# Altium schematic sheet SW6208+A+A+B+C+L+快充188数码管.SchDoc
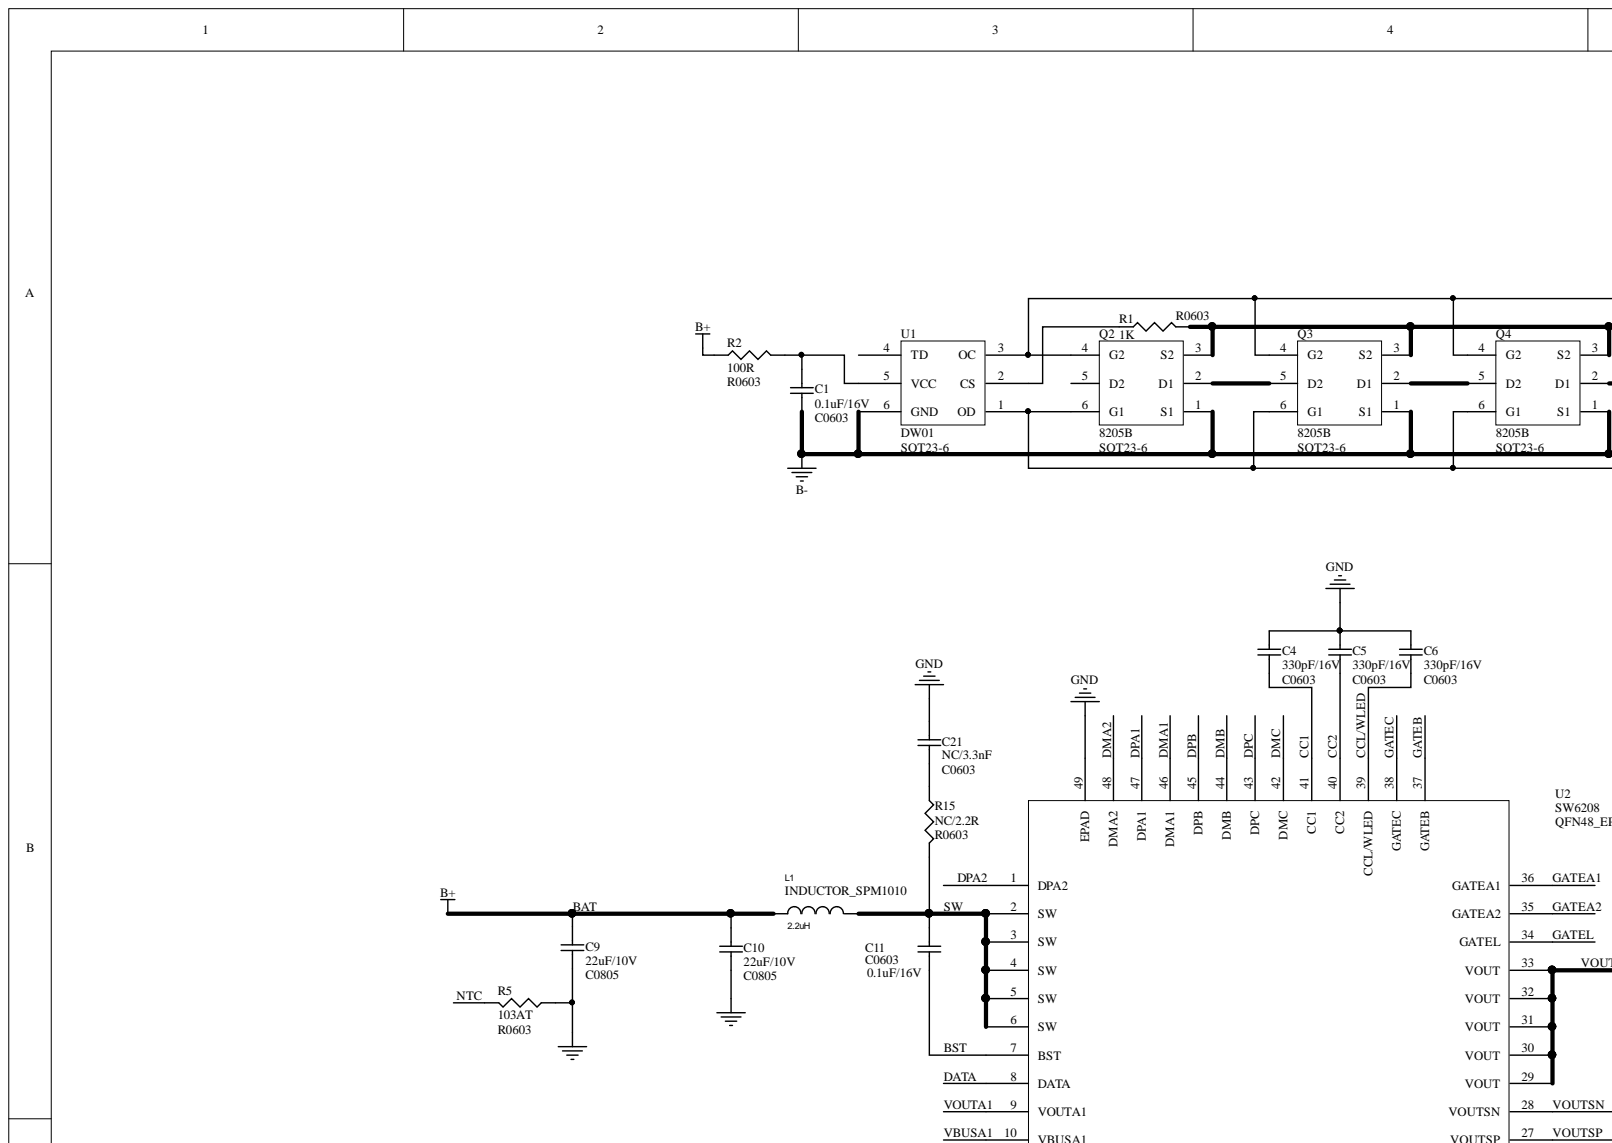
[diagram p0: SW6208+A+A+B+C+L+快充188数码管.SchDoc, top left]
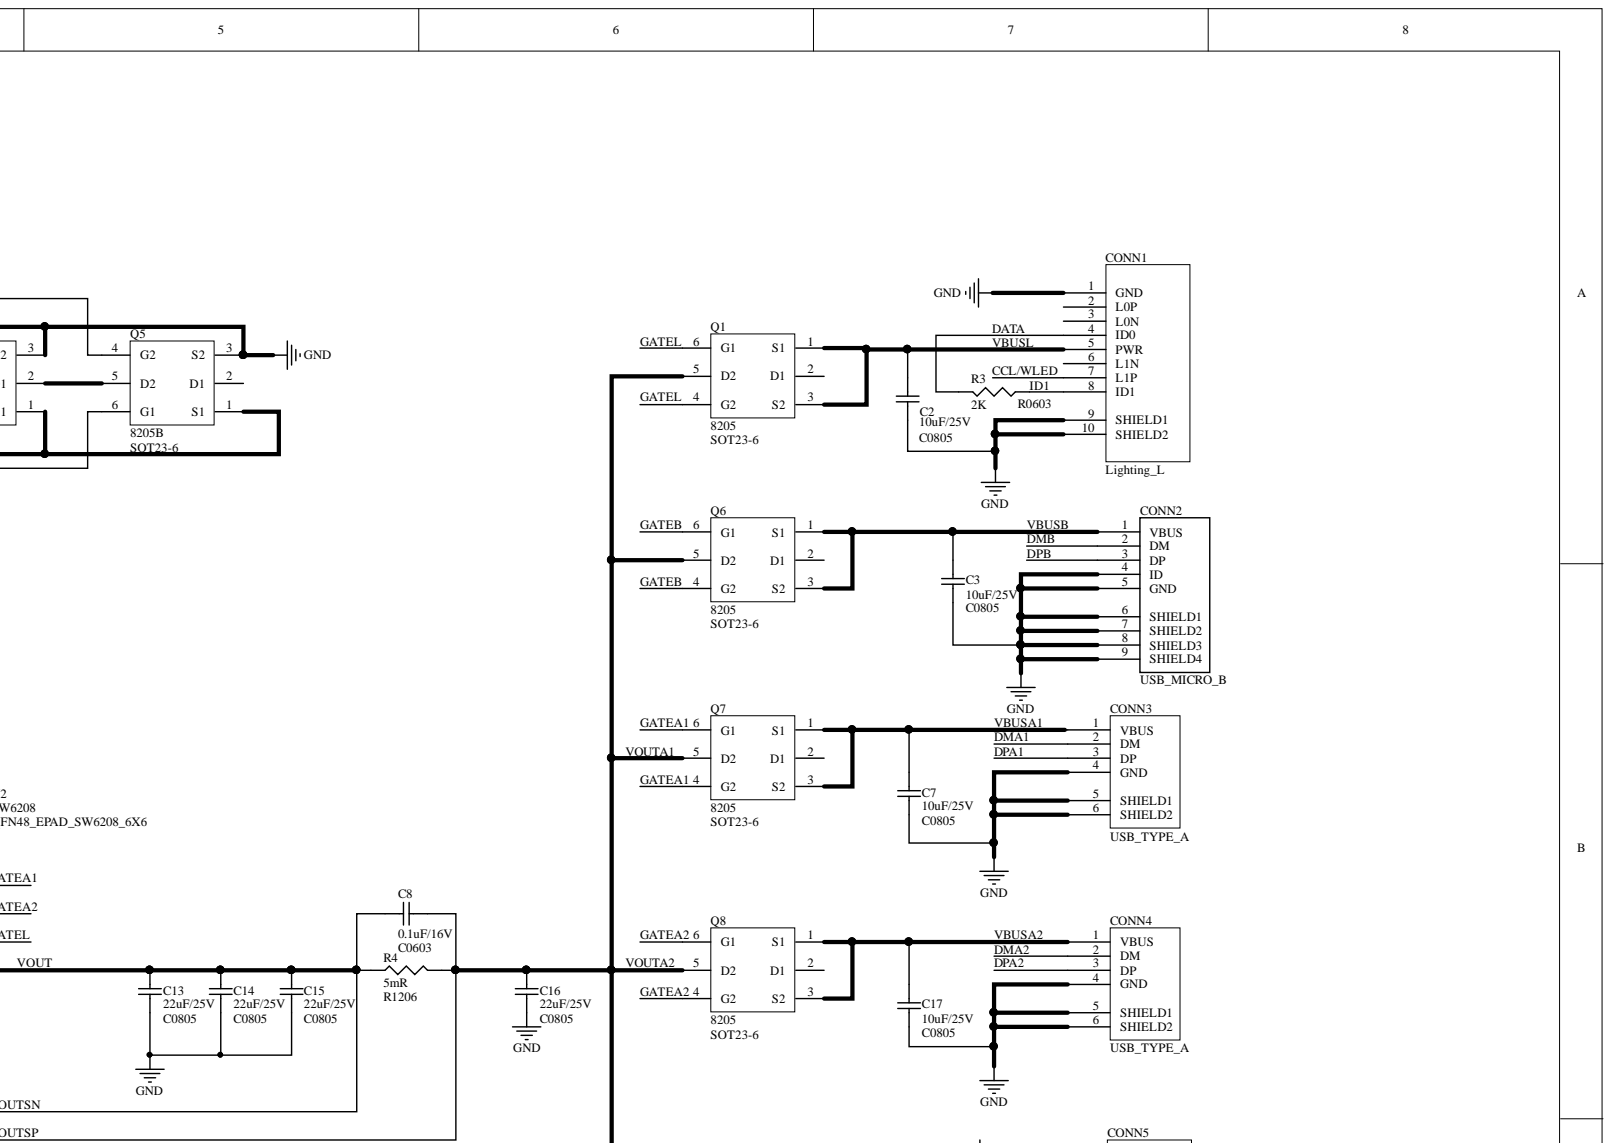
[diagram p1: SW6208+A+A+B+C+L+快充188数码管.SchDoc, top right]
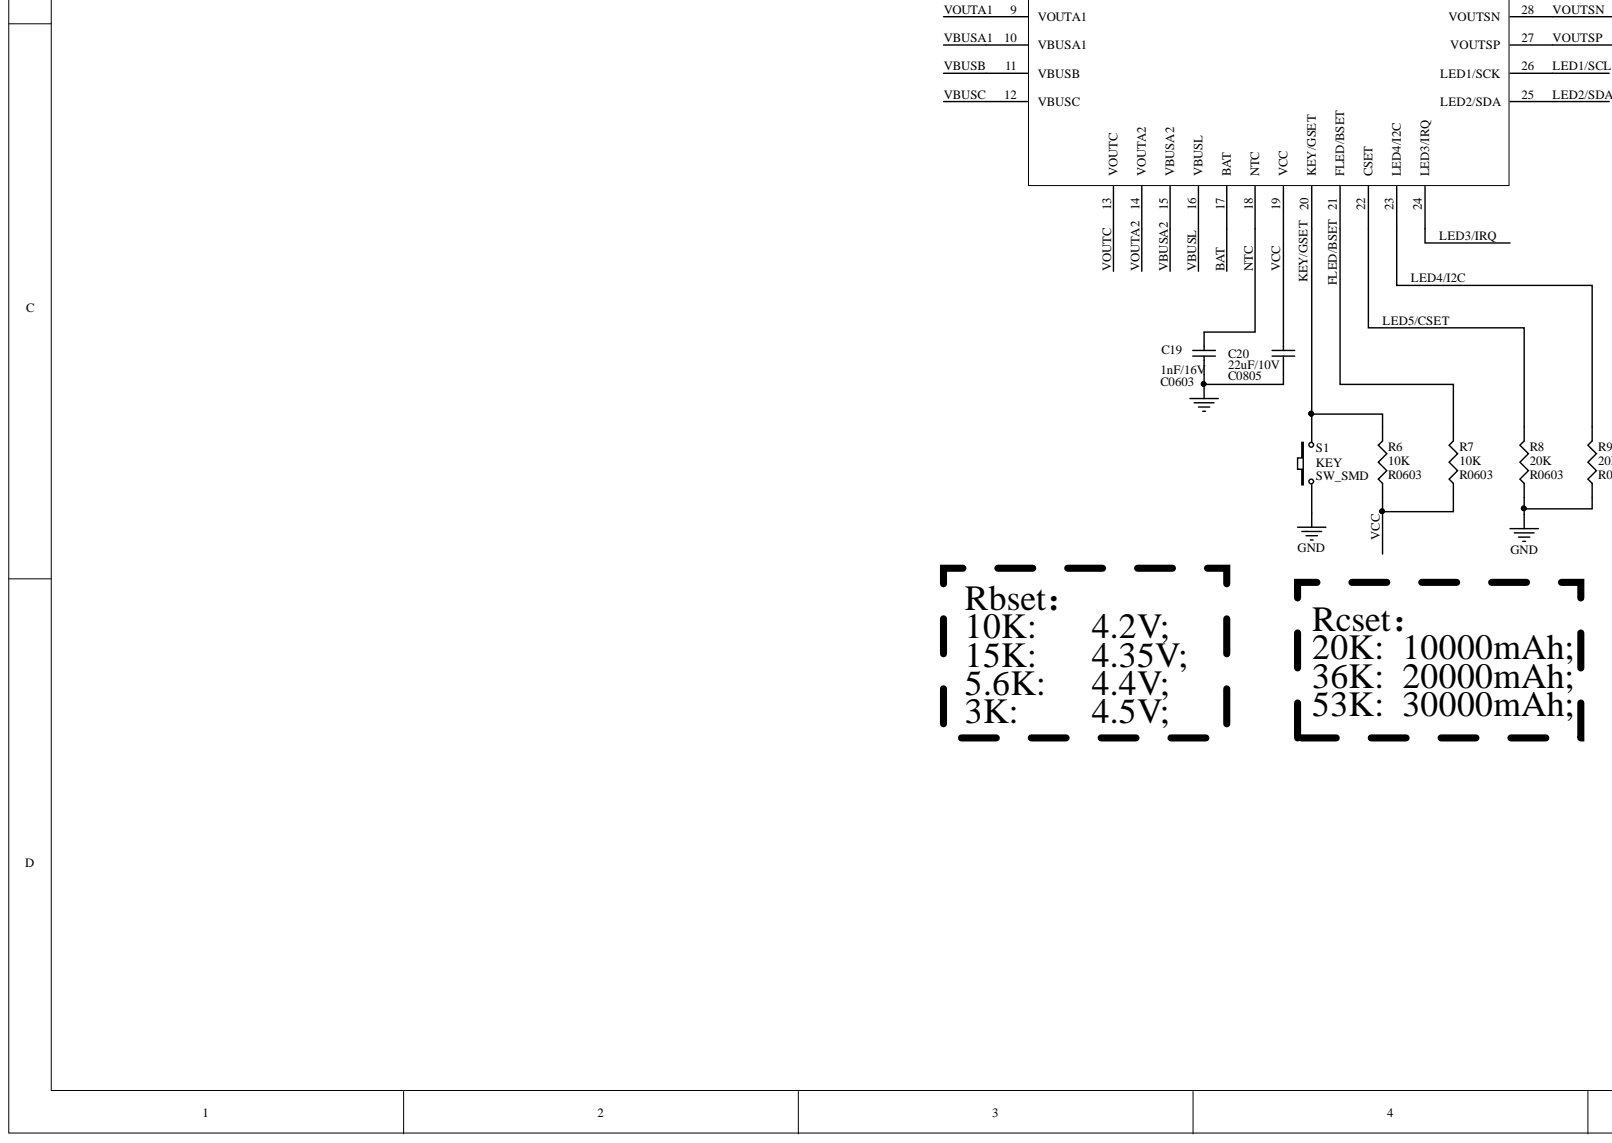
[diagram p2: SW6208+A+A+B+C+L+快充188数码管.SchDoc, bottom left]
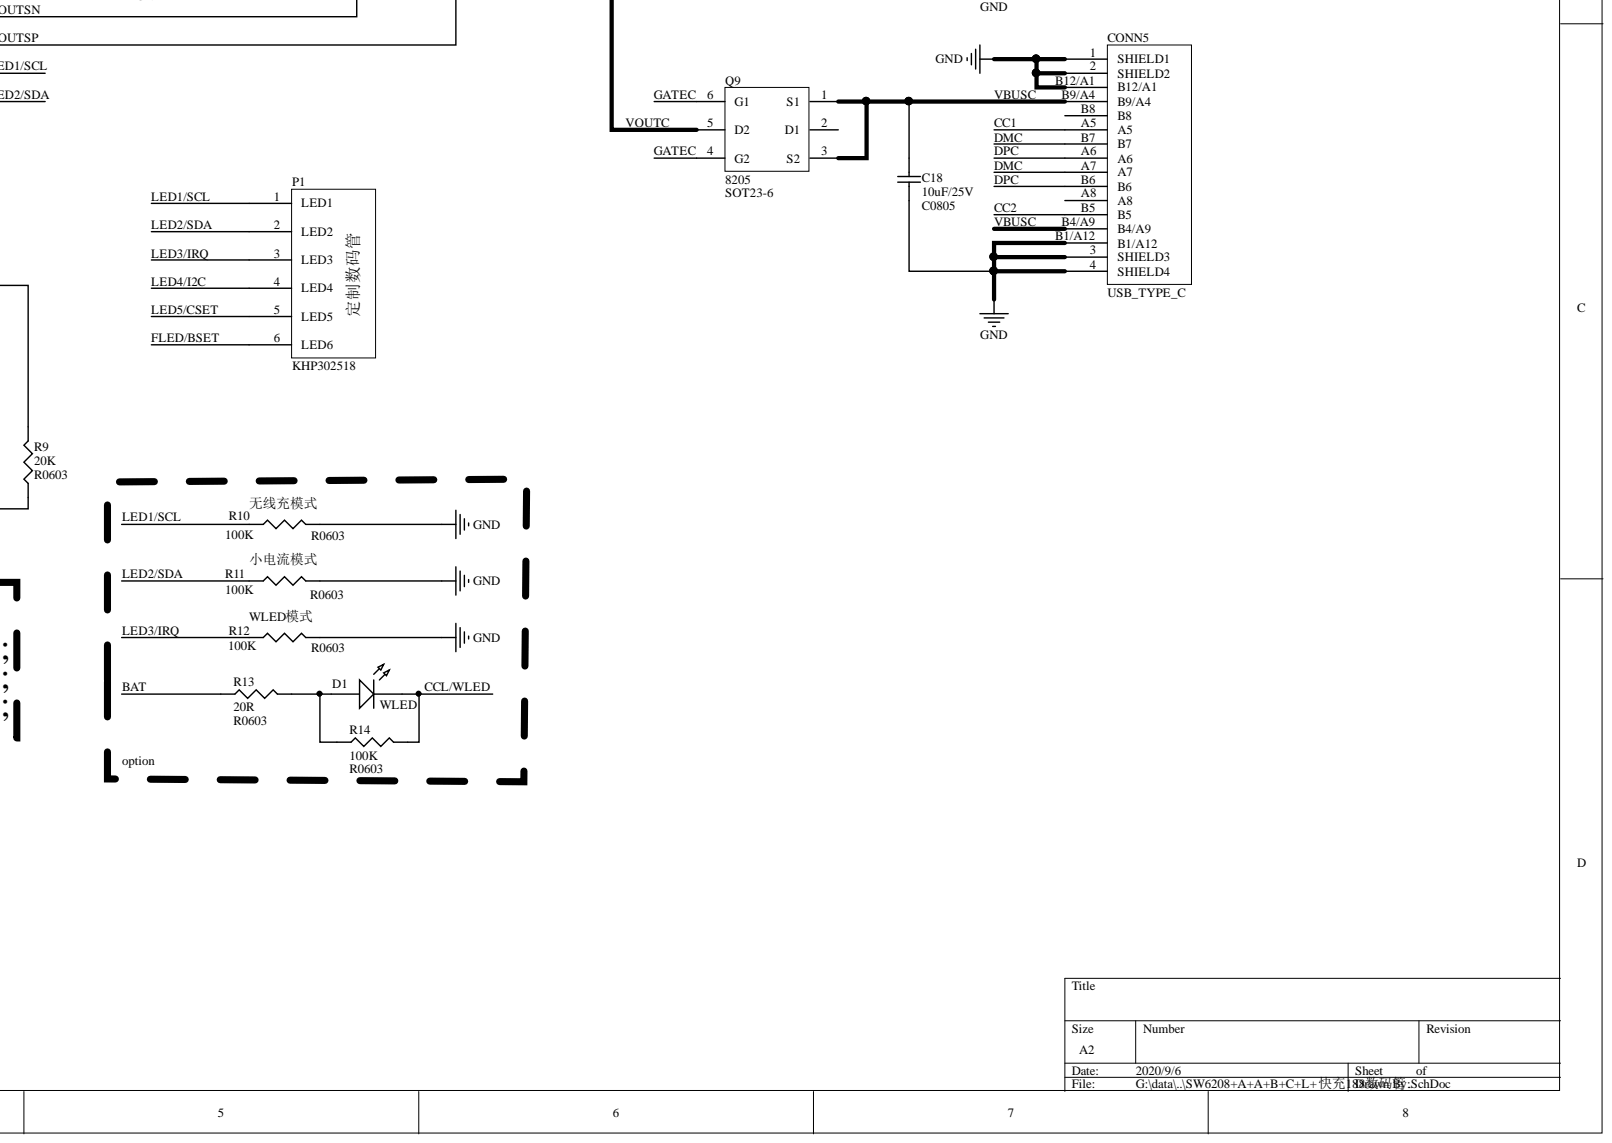
[diagram p3: SW6208+A+A+B+C+L+快充188数码管.SchDoc, bottom right]

## Parts
C1  0.1uF/16V  [C0603]
C2  10uF/25V  [C0805]
C3  10uF/25V  [C0805]
C4  330pF/16V  [C0603]
C5  330pF/16V  [C0603]
C6  330pF/16V  [C0603]
C7  10uF/25V  [C0805]
C8  0.1uF/16V  [C0603]
C9  22uF/10V  [C0805]
C10  22uF/10V  [C0805]
C11  0.1uF/16V  [C0603]
C13  22uF/25V  [C0805]
C14  22uF/25V  [C0805]
C15  22uF/25V  [C0805]
C16  22uF/25V  [C0805]
C17  10uF/25V  [C0805]
C18  10uF/25V  [C0805]
C19  1nF/16V  [C0603]
C20  22uF/10V  [C0805]
C21  NC/3.3nF  [C0603]
CONN1  Lighting_L  [Lighting_L]
CONN2  USB_MICRO_B  [USB_MICRO_B]
CONN3  USB_TYPE_A  [USB_TYPE_A]
CONN4  USB_TYPE_A  [USB_TYPE_A]
CONN5  USB_TYPE_C  [USB_TYPE_C]
D1  WLED  [LED_5MM]
L1  2.2uH  [INDUCTOR_SPM1010]
P1  KHP302518  [KHP-SEG-6]
Q1  8205  [SOT23-6]
Q2  8205B  [SOT23-6]
Q3  8205B  [SOT23-6]
Q4  8205B  [SOT23-6]
Q5  8205B  [SOT23-6]
Q6  8205  [SOT23-6]
Q7  8205  [SOT23-6]
Q8  8205  [SOT23-6]
Q9  8205  [SOT23-6]
R1  1K  [R0603]
R2  100R  [R0603]
R3  2K  [R0603]
R4  5mR  [R1206]
R5  103AT  [R0603]
R6  10K  [R0603]
R7  10K  [R0603]
R8  20K  [R0603]
R9  20K  [R0603]
R10  100K  [R0603]
R11  100K  [R0603]
R12  100K  [R0603]
R13  20R  [R0603]
R14  100K  [R0603]
R15  NC/2.2R  [R0603]
S1  KEY  [SW_SMD]
U1  DW01  [SOT23-6]
U2  SW6208  [QFN48_EPAD_SW6208_6X6]

## Nets
B-: C1.1 Q2.1(S1) Q3.1(S1) Q4.1(S1) Q5.1(S1) U1.6(GND)
GND: C2.1 C3.1 C4.2 C5.2 C6.2 C7.1 C9.1 C10.1 C13.1 C14.1 C15.1 C16.1 C17.1 C18.1 C19.2 C20.2 C21.2 CONN1.1(GND) CONN1.9(SHIELD1) CONN1.10(SHIELD2) CONN2.4(ID) CONN2.5(GND) CONN2.6(SHIELD1) CONN2.7(SHIELD2) CONN2.8(SHIELD3) CONN2.9(SHIELD4) CONN3.4(GND) CONN3.5(SHIELD1) CONN3.6(SHIELD2) CONN4.4(GND) CONN4.5(SHIELD1) CONN4.6(SHIELD2) CONN5.1(SHIELD1) CONN5.2(SHIELD2) CONN5.3(SHIELD3) CONN5.4(SHIELD4) CONN5.B1/A12 CONN5.B12/A1 Q2.3(S2) Q3.3(S2) Q4.3(S2) Q5.3(S2) R1.2 R5.1 R8.1 R9.1 R10.2 R11.2 R12.2 S1.1 U2.49(EPAD)
BAT: C9.2 C10.2 L1.1 R2.1 R13.1 U2.17(BAT)
BST: C11.1 U2.7(BST)
CC1: C4.1 CONN5.A5 U2.41(CC1)
CC2: C5.1 CONN5.B5 U2.40(CC2)
CCL/WLED: C6.1 CONN1.7(L1P) D1.2 R14.2 U2.39(CCL/WLED)
DATA: CONN1.4(ID0) R3.2 U2.8(DATA)
DMA1: CONN3.2(DM) U2.46(DMA1)
DMA2: CONN4.2(DM) U2.48(DMA2)
DMB: CONN2.2(DM) U2.44(DMB)
DMC: CONN5.A7 CONN5.B7 U2.42(DMC)
DPA1: CONN3.3(DP) U2.47(DPA1)
DPA2: CONN4.3(DP) U2.1(DPA2)
DPB: CONN2.3(DP) U2.45(DPB)
DPC: CONN5.A6 CONN5.B6 U2.43(DPC)
FLED/BSET: P1.6(LED6) R7.2 U2.21(FLED/BSET)
GATEA1: Q7.4(G2) Q7.6(G1) U2.36(GATEA1)
GATEA2: Q8.4(G2) Q8.6(G1) U2.35(GATEA2)
GATEB: Q6.4(G2) Q6.6(G1) U2.37(GATEB)
GATEC: Q9.4(G2) Q9.6(G1) U2.38(GATEC)
GATEL: Q1.4(G2) Q1.6(G1) U2.34(GATEL)
ID1: CONN1.8(ID1) R3.1
LED1/SCL: P1.1(LED1) R10.1 U2.26(LED1/SCK)
LED2/SDA: P1.2(LED2) R11.1 U2.25(LED2/SDA)
LED3/IRQ: P1.3(LED3) R12.1 U2.24(LED3/IRQ)
LED4/I2C: P1.4(LED4) R9.2 U2.23(LED4/I2C)
LED5/CSET: P1.5(LED5) R8.2 U2.22(CSET)
NTC: C19.1 R5.2 U2.18(NTC)
SW: C11.2 L1.2 R15.2 U2.2(SW) U2.3(SW) U2.4(SW) U2.5(SW) U2.6(SW)
VBUSA1: C7.2 CONN3.1(VBUS) Q7.1(S1) Q7.3(S2) U2.10(VBUSA1)
VBUSA2: C17.2 CONN4.1(VBUS) Q8.1(S1) Q8.3(S2) U2.15(VBUSA2)
VBUSB: C3.2 CONN2.1(VBUS) Q6.1(S1) Q6.3(S2) U2.11(VBUSB)
VBUSC: C18.2 CONN5.B4/A9 CONN5.B9/A4 Q9.1(S1) Q9.3(S2) U2.12(VBUSC)
VBUSL: C2.2 CONN1.5(PWR) Q1.1(S1) Q1.3(S2) U2.16(VBUSL)
VCC: C20.1 R6.1 R7.1 U2.19(VCC)
VOUT: C8.1 C13.2 C14.2 C15.2 R4.1 U2.28(VOUTSN) U2.29(VOUT) U2.30(VOUT) U2.31(VOUT) U2.32(VOUT) U2.33(VOUT)
VOUTA1: C8.2 C16.2 Q1.5(D2) Q6.5(D2) Q7.5(D2) Q8.5(D2) Q9.5(D2) R4.2 U2.9(VOUTA1) U2.13(VOUTC) U2.14(VOUTA2) U2.27(VOUTSP)
NetC1_2: C1.2 R2.2 U1.5(VCC)
NetC21_1: C21.1 R15.1
NetD1_1: D1.1 R13.2 R14.1
NetQ2_2: Q2.2(D1) Q3.5(D2)
NetQ2_4: Q2.4(G2) Q3.4(G2) Q4.4(G2) Q5.4(G2) U1.3(OC)
NetQ2_6: Q2.6(G1) Q3.6(G1) Q4.6(G1) Q5.6(G1) U1.1(OD)
NetQ3_2: Q3.2(D1) Q4.5(D2)
NetQ4_2: Q4.2(D1) Q5.5(D2)
NetR1_1: R1.1 U1.2(CS)
NetR6_2: R6.2 S1.2 U2.20(KEY/GSET)
KEY/GSET:

Not connected: CONN1 2(L0P) 3(L0N) 6(L1N); CONN5 A8 B8; Q1 2(D1); Q2 5(D2); Q5 2(D1); Q6 2(D1); Q7 2(D1); Q8 2(D1); Q9 2(D1); U1 4(TD)

## Library data of the parts
C1 C4-C6 C8 C11 C19 C21 = CAP0603: Capacitor; Value: 22uF/16V; Component Kind: Standard; LatestRevisionDate: 17-Jul-2002; LatestRevisionNote: Re-released for DXP Platform.; PackageDescription: Radial Cap, Thru-Hole; 2 Leads; 0.3 in Pin Spacing; PackageReference: RAD-0.3; Published: 8-Jun-2000; Library Name: 参考设计器件原理图更新.SchLib; Publisher: Altium Limited
C2 C3 C7 C9 C10 C17 C18 C20 = CAP0805: Capacitor; Value: 22uF/25V; Component Kind: Standard; LatestRevisionDate: 17-Jul-2002; LatestRevisionNote: Re-released for DXP Platform.; PackageDescription: Radial Cap, Thru-Hole; 2 Leads; 0.3 in Pin Spacing; PackageReference: RAD-0.3; Published: 8-Jun-2000; Library Name: 参考设计器件原理图更新.SchLib; Publisher: Altium Limited
C13-C16 = CAP0805: Capacitor; Component Kind: Standard; LatestRevisionDate: 17-Jul-2002; LatestRevisionNote: Re-released for DXP Platform.; PackageDescription: Radial Cap, Thru-Hole; 2 Leads; 0.3 in Pin Spacing; PackageReference: RAD-0.3; Published: 8-Jun-2000; Value: 22uF/25V; Library Name: 参考设计器件原理图更新.SchLib; Publisher: Altium Limited
CONN1 = LIGHTING_PORT: Component Kind: Standard; Description: Lighting_L; Value: Lighting_L; Library Name: 参考设计器件原理图更新.SchLib
D1 = WLED: Value: FLED; Component Kind: Standard; Description: WLED; LatestRevisionDate: 08-Jul-2005; LatestRevisionNote: Mech Layer 1 removed.; PackageDescription: LED; 2 Leads; PackageReference: LED-0; Published: 8-Jun-2000; Library Name: 参考设计器件原理图更新.SchLib; Publisher: Altium Limited
L1 = INDUCTOR_SPM1010: INDUCTOR; Component Kind: Standard; Value: 2.2uH; Library Name: 参考设计器件原理图更新.SchLib
Q1 Q6-Q9 = 8205: NMOS; Component Kind: Standard; Footprinf: SOT23-6; Value: 8205; Library Name: 参考设计器件原理图更新.SchLib
Q2-Q5 = 8205: NMOS; Value: 8205; Component Kind: Standard; Footprinf: SOT23-6; Library Name: 参考设计器件原理图更新.SchLib
R1-R3 R5 R8-R15 = RES_0603: Resistor; Value: 10K; Component Kind: Standard; LatestRevisionDate: 29-May-2009; LatestRevisionNote: IPC-7351 Footprint Added.; PackageDescription: Chip Resistor; PackageReference: J1-0603; Published: 8-Jun-2000; Library Name: 参考设计器件原理图更新.SchLib; Publisher: Altium Limited
R4 = RES_1206: Resistor; Value: 10K; Component Kind: Standard; LatestRevisionDate: 29-May-2009; LatestRevisionNote: IPC-7351 Footprint Added.; PackageDescription: Chip Resistor; PackageReference: J1-0603; Published: 8-Jun-2000; Library Name: 参考设计器件原理图更新.SchLib; Publisher: Altium Limited
R6 R7 = RES_0603: Resistor; Component Kind: Standard; LatestRevisionDate: 29-May-2009; LatestRevisionNote: IPC-7351 Footprint Added.; PackageDescription: Chip Resistor; PackageReference: J1-0603; Published: 8-Jun-2000; Value: 10K; Library Name: 参考设计器件原理图更新.SchLib; Publisher: Altium Limited
S1 = SW K: Component Kind: Standard; Description: KEY; Footprinf: SW_SMD; Value: KEY; Library Name: 参考设计器件原理图更新.SchLib
U1 = DW01: BAT_PROTECT_IC; Component Kind: Standard; Value: DW01; Library Name: 参考设计器件原理图更新.SchLib
U2 = SW6208: IC; Component Kind: Standard; Library Name: 芯片型号原理图.SchLib
CONN2 = USB_MICRO_B: Component Kind: Standard; Description: USB_MICRO_B; Footprintf: MICRO_B; Value: USB_MICRO_B; Library Name: 参考设计器件原理图更新.SchLib
CONN3 CONN4 = USB_TYPE_A: Component Kind: Standard; Description: USB_TYPE_A; Library Name: 参考设计器件原理图更新.SchLib
CONN5 = USB_TYPE_C: Component Kind: Standard; Description: USB_TYPE_C; Value: USB_TYPE_C; Library Name: 参考设计器件原理图更新.SchLib
P1 = KHP302518: Component Kind: Standard; Description: KHP302518; Library Name: 参考设计器件原理图更新.SchLib
